# Altium schematic sheet Motor-X.SchDoc(Motor-X)
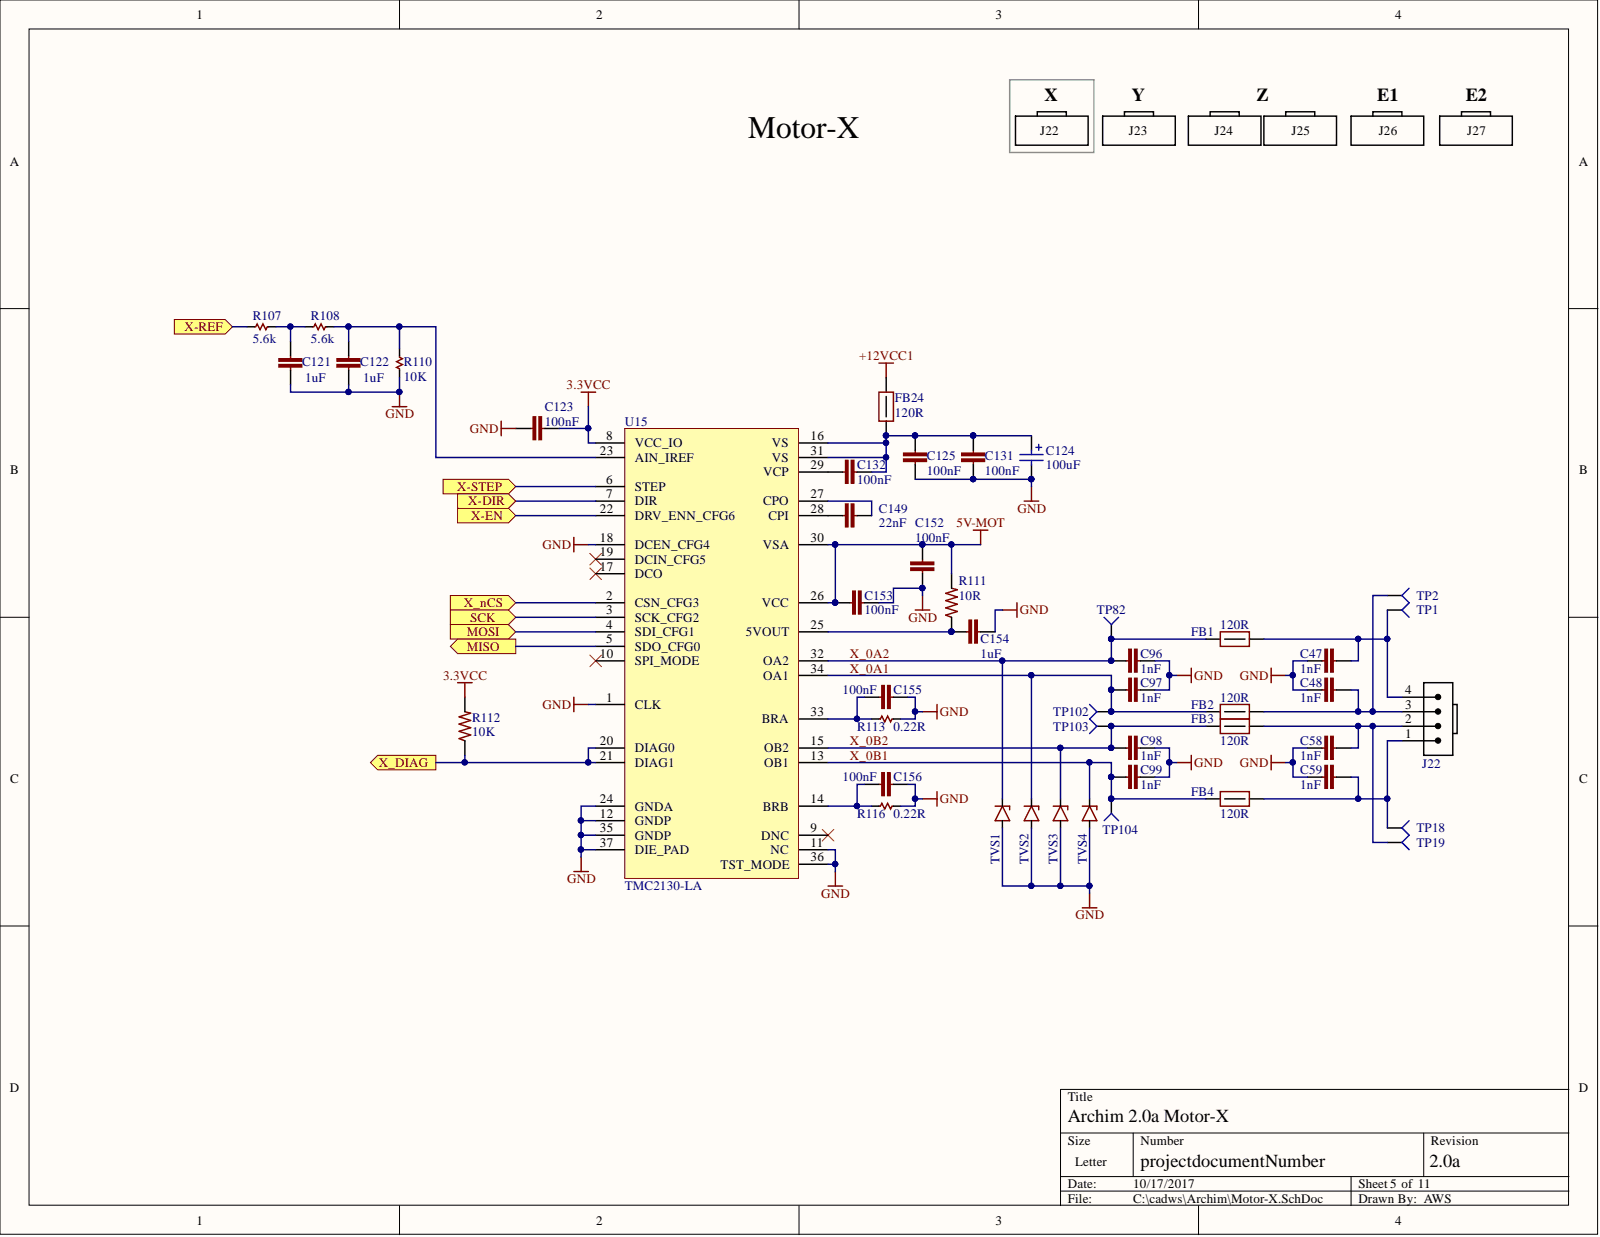
[diagram p0: Motor-X.SchDoc]

## Parts
C47  1nF  [C0402]
C48  1nF  [C0402]
C58  1nF  [C0402]
C59  1nF  [C0402]
C96  1nF  [C0402]
C97  1nF  [C0402]
C98  1nF  [C0402]
C99  1nF  [C0402]
C121  1uF  [C0402]
C122  1uF  [C0402]
C123  100nF  [C0402]
C124  value 100uF  [CAP-ELEC-063063077]  (hidden comment: UWT1V101MCL1GS)
C125  100nF  [C0603]
C131  100nF  [C0603]
C132  100nF  [C0603]
C149  22nF  [C0402]
C152  100nF  [C0402]
C153  100nF  [C0402]
C154  1uF  [C0402]
C155  100nF  [C0603]
C156  100nF  [C0603]
FB1  120R  [FB0603]
FB2  120R  [FB0603]
FB3  120R  [FB0603]
FB4  120R  [FB0603]
FB24  120R  [FB0603]
J22  Molex 0705430003  [4POL254]  (value not drawn)
R107  5.6k  [R0402]
R108  5.6k  [R0402]
R110  10K  [R0402]
R111  10R  [R0402]
R112  10K  [R0402]
R113  0.22R  [R1206]
R116  0.22R  [R1206]
TP1  TP4  [TP-2]  (value not drawn)  (no BOM)
TP2  TP4  [TP-2]  (value not drawn)  (no BOM)
TP18  TP4  [TP-2]  (value not drawn)  (no BOM)
TP19  TP4  [TP-2]  (value not drawn)  (no BOM)
TP82  TP4  [TP-2]  (value not drawn)  (no BOM)
TP102  TP4  [TP-2]  (value not drawn)  (no BOM)
TP103  TP4  [TP-2]  (value not drawn)  (no BOM)
TP104  TP4  [TP-2]  (value not drawn)  (no BOM)
TVS1  TVS 30V SMF  [SMF]  (value not drawn)
TVS2  TVS 30V SMF  [SMF]  (value not drawn)
TVS3  TVS 30V SMF  [SMF]  (value not drawn)
TVS4  TVS 30V SMF  [SMF]  (value not drawn)
U15  TMC2130-LA  [QFN36-50P500X600X900_HS-37N]

## Ports
MISO, MOSI, SCK, X-DIR, X-EN, X-REF, X-STEP, X_DIAG, X_nCS

## Nets
3.3VCC: C123.1 R112.2 U15.8(VCC_IO)
5V-MOT: C152.2 C153.2 R111.2 U15.26(VCC) U15.30(VSA)
+12VCC1: FB24.2
GND: C47.1 C48.1 C58.1 C59.1 C96.2 C97.2 C98.2 C99.2 C121.2 C122.2 C123.2 C124.2 C125.1 C131.1 C152.1 C153.1 C154.2 C155.1 C156.1 R110.1 R113.2 R116.2 TVS1.A TVS2.A TVS3.A TVS4.A U15.1(CLK) U15.11(NC) U15.12(GNDP) U15.18(DCEN_CFG4) U15.24(GNDA) U15.35(GNDP) U15.36(TST_MODE) U15.37(DIE_PAD)
MISO: U15.5(SDO_CFG0) ; port MISO
MOSI: U15.4(SDI_CFG1) ; port MOSI
SCK: U15.3(SCK_CFG2) ; port SCK
X-DIR: U15.7(DIR) ; port X-DIR
X-EN: U15.22(DRV_ENN_CFG6) ; port X-EN
X-REF: R107.1 ; port X-REF
X-STEP: U15.6(STEP) ; port X-STEP
X_0A1: C97.1 FB2.1 TP102.1 TVS2.K U15.34(OA1)
X_0A2: C96.1 FB1.1 TP82.1 TVS1.K U15.32(OA2)
X_0B1: C99.1 FB4.1 TP104.1 TVS4.K U15.13(OB1)
X_0B2: C98.1 FB3.1 TP103.1 TVS3.K U15.15(OB2)
X_DIAG: R112.1 U15.20(DIAG0) U15.21(DIAG1) ; port X_DIAG
X_nCS: U15.2(CSN_CFG3) ; port X_nCS
NetC47_2: C47.2 FB1.2 J22.4 TP1.1
NetC48_2: C48.2 FB2.2 J22.3 TP2.1
NetC58_2: C58.2 FB3.2 J22.2 TP19.1
NetC59_2: C59.2 FB4.2 J22.1 TP18.1
NetC121_1: C121.1 R107.2 R108.1
NetC122_1: C122.1 R108.2 R110.2 U15.23(AIN_IREF)
NetC124_1: C124.1 C125.2 C131.2 C132.2 FB24.1 U15.16(VS) U15.31(VS)
NetC132_1: C132.1 U15.29(VCP)
NetC149_1: C149.1 U15.28(CPI)
NetC149_2: C149.2 U15.27(CPO)
NetC154_1: C154.1 R111.1 U15.25(5VOUT)
NetC155_2: C155.2 R113.1 U15.33(BRA)
NetC156_2: C156.2 R116.1 U15.14(BRB)

Not connected: U15 9(DNC) 10(SPI_MODE) 17(DCO) 19(DCIN_CFG5)

## Library data of the parts
C47 C48 C58 C59 C96-C99 = CAP 1nF 50V 0402 X7R: 1000pF 50V Ceramic Capacitor X7R 0402 (1005 Metric) 0.039" L x 0.020" W (1.00mm x 0.50mm); PART DESCRIPTION: 1000pF ±10% 50V Ceramic Capacitor X7R 0402 (1005 Metric); PART: 1nF 50V X7R 0402; MANUFACTURER #: GRM155R71H102KA01D; MANUFACTURER: Murata; TOLERANCE: 10%; VALUE: 1nF; Library Name: 3Delight.SCHLIB; EE_SPEC: 50V; DISTRIBUTOR #: 490-1303-2-ND; DISTRIBUTOR: Digi-Key Electronics; Component Kind: Standard
C121 C122 = GRM155R60J105KE19D: CAP CER 0402 1uF 6.3V 10% X5R; PART DESCRIPTION: 1µF ±10% 6.3V Ceramic Capacitor X5R 0402 (1005 Metric); PART: 1uF 6.3V X5R 0402; MANUFACTURER: Murata; VALUE: 1uF; TOLERANCE: 10%; Simulation: Model Name; Signal Integrity: Model Name; MANUFACTURER #: GRM155R60J105KE19D; Library Name: 3Delight.SCHLIB; EE_SPEC: 1uF 6.3V X5R 0402; DISTRIBUTOR #: 490-1320-2-ND; DISTRIBUTOR: Digi-Key Electronics; Component Kind: Standard
C123 C152 C153 = GRM155R71C104KA88D: CAP CER 0402 100nF 16V 10% X7R; Part Description: 0.10µF ±10% 16V Ceramic Capacitor X7R 0402 (1005 Metric); PART: 0.1uF 16V X7R 0402; Manufacturer: Murata; EE_SPEC: 100nF 16V X7R 0402; VALUE: 100nF; Tolerance: 10%; Simulation: Model Name; Signal Integrity: Model Name; MANUFACTURER #: GRM155R71C104KA88D; Library Name: 3Delight.SCHLIB; DISTRIBUTOR #: 490-3261-2-ND; DISTRIBUTOR: Digi-Key Electronics; Component Kind: Standard
C124 = UWT1V101MCL1GS: CAP ALUM 100UF 35V 20% SMD; Part Description: 100µF 35V Aluminum Capacitors Radial, Can - SMD 1000 Hrs @ 105°C; PART: 100uF 35V SMD Al-El; Manufacturer: Nichicon; EE_SPEC: 100uF 35V Al-El; Tolerance: 20%; Simulation: CAP; Signal Integrity: Cap Pol; MANUFACTURER #: UWT1V101MCL1GS; Library Name: 3Delight.SCHLIB; DISTRIBUTOR #: 493-2203-2-ND; DISTRIBUTOR: Digi-Key Electronics; Component Kind: Standard
C125 C131 C132 C155 C156 = C0603C104K5RACTU: CAP CER 0603 100nF 50V 10% X7R; Part Description: 0.1µF ±10% 50V Ceramic Capacitor X7R 0603 (1608 Metric); PART: 100nF 50V X7R 0603; Manufacturer: Kemet; VALUE: 100nF; Tolerance: 10%; Simulation: Model Name; Signal Integrity: Model Name; MANUFACTURER #: C0603C104K5RACTU; Library Name: 3Delight.SCHLIB; EE_SPEC: 100nF 50V X7R 0603; DISTRIBUTOR #: 399-5089-2-ND; DISTRIBUTOR: Digi-Key Electronics; Component Kind: Standard
C149 = GCM155R71H223KA55D: CAP CER 0402 22nF ±10% 50V X7R; Part Description: 0.022µF ±10% 50V Ceramic Capacitor X7R 0402 (1005 Metric); Manufacturer: YAGEO; Tolerance: +/- 10%; EE_SPEC: 22nF 50V X7R 0402; VALUE: 22nF; Simulation: Model Name; Signal Integrity: Model Name; PART: 22nF; MANUFACTURER #: GCM155R71H223KA55D; Library Name: 3Delight.SCHLIB; DISTRIBUTOR #: 490-4763-2-ND; DISTRIBUTOR: Digi-Key Electronics; Component Kind: Standard
C154 = GRM155R60J105KE19D: CAP CER 0402 1uF 6.3V 10% X5R; Part Description: 1µF ±10% 6.3V Ceramic Capacitor X5R 0402 (1005 Metric); PART: 1uF 6.3V X5R 0402; Manufacturer: Murata; VALUE: 1uF; Tolerance: 10%; Simulation: Model Name; Signal Integrity: Model Name; MANUFACTURER #: GRM155R60J105KE19D; Library Name: 3Delight.SCHLIB; EE_SPEC: 1uF 6.3V X5R 0402; DISTRIBUTOR #: 490-1320-2-ND; DISTRIBUTOR: Digi-Key Electronics; Component Kind: Standard
FB1-FB4 = FERRITE 120R 3A 0603: FERRITE BEAD 120 OHM 0603 1LN; MANUFACTURER #: CIS10P121AC; MANUFACTURER2#: 490-5254-2-ND; MANUFACTURER: Samsung; VALUE: 120Rfb; TOLERANCE: -NA-; EE_SPEC: 3A; PART DESCRIPTION: FERRITE BEAD 120 OHM 0603 1LN; PART: FERRITE 120R 3A 0603; Library Name: 3Delight.SCHLIB; DISTRIBUTOR #: 1276-6351-2-ND; DISTRIBUTOR: Digi-Key Electronics; Component Kind: Standard
FB24 = FERRITE 120R 3A 0603: FERRITE BEAD 120 OHM 0603 1LN; MANUFACTURER #: CIS10P121AC; MANUFACTURER: Samsung; VALUE: 120Rfb; TOLERANCE: -NA-; EE_SPEC: 3A; PART DESCRIPTION: FERRITE BEAD 120 OHM 0603 1LN; PART: FERRITE 120R 3A 0603; Library Name: Inductors.SchLib; DISTRIBUTOR #: 1276-6351-2-ND; DISTRIBUTOR: Digi-Key Electronics; Component Kind: Standard
J22 = Molex 0705430003 Alt_1: Molex 4 Pin Clip Connector; PART DESCRIPTION: 4 Positions Header, Shrouded Connector 0.100" (2.54mm) Through Hole Tin; PART: 4 Pin Conn; MANUFACTURER #: 0705430038; VALUE: HDR4; TOLERANCE: -NA-; MANUFACTURER: Molex; EE_SPEC: -NA-; DISTRIBUTOR #: WM4826-ND; DISTRIBUTOR: Digi-Key Electronics; Component Kind: Standard; Library Name: Archim.SCHLIB
R107 R108 = 5.6k 1% 0402: RES SMD 5.6K OHM 1% 1/16W 0402; PART DESCRIPTION: ±1% 0.063W, 1/16W Chip Resistor 0402 (1005 Metric) Moisture Resistant Thick Film; MANUFACTURER #: RC0402FR-075K6L; MANUFACTURER: YAGEO; VALUE: 5.6k; TOLERANCE: 1%; PART: RES SMD 5.6K OHM 1% 1/16W 0402; Library Name: Resistors.SchLib; EE_SPEC: 1/16W; DISTRIBUTOR #: 311-5.6KLRTR-ND; DISTRIBUTOR: Digi-Key Electronics; Component Kind: Standard
R110 = 10k 1% 0402: RES SMD 10K OHM 1% 1/16W 0402; PART DESCRIPTION: 10k Ohm ±1% 0.063W, 1/16W Chip Resistor 0402 (1005 Metric) Moisture Resistant Thick Film; MANUFACTURER #: RC0402FR-0710KP; MANUFACTURER: Yageo; VALUE: 10K; TOLERANCE: 1%; Simulation: Model Name; Signal Integrity: Model Name; PART: 10k 1% 0402; Library Name: Resistors.SchLib; EE_SPEC: 1/16W; DISTRIBUTOR #: YAG1249TR-ND; DISTRIBUTOR: Digi-Key Electronics; Component Kind: Standard
R111 = RC0402FR-0710RL: RES SMD 10 OHM 1% 1/16W 0402; Part Description: 10 Ohm ±1% 0.063W, 1/16W Chip Resistor 0402 (1005 Metric) Moisture Resistant Thick Film; Manufacturer: Yageo; EE_SPEC: 10R 1% 0402; VALUE: 10R; Tolerance: 1%; Simulation: Model Name; Signal Integrity: Model Name; PART: 10R; MANUFACTURER #: RC0402FR-0710RL; Library Name: 3Delight.SCHLIB; DISTRIBUTOR #: 311-10.0LRTR-ND; DISTRIBUTOR: Digi-Key Electronics; Component Kind: Standard
R112 = RC0402FR-0710KP: RES SMD 10K OHM 1% 1/16W 0402; PART DESCRIPTION: 10k Ohm ±1% 0.063W, 1/16W Chip Resistor 0402 (1005 Metric) Moisture Resistant Thick Film; PART: 10k 1% 0402; MANUFACTURER: Yageo; VALUE: 10K; TOLERANCE: 1%; Simulation: Model Name; Signal Integrity: Model Name; MANUFACTURER #: RC0402FR-0710KP; Library Name: 3Delight.SCHLIB; EE_SPEC: 1/16W; DISTRIBUTOR #: YAG1249TR-ND; DISTRIBUTOR: Digi-Key Electronics; Component Kind: Standard
R113 R116 = 0.22R 1% 1206: RES SMD 0.22 OHM 1% 1/2W 1206; Part Description: 0.22 Ohm ±1% 0.5W, 1/2W Chip Resistor 1206 (3216 Metric) Automotive AEC-Q200, Current Sense Thick Film; MANUFACTURER #: ERJ-8BQFR22V; Manufacturer: Panasonic; Tolerance: +/- 1%; VALUE: 0.22R; PART: 0.22R; Library Name: Resistors.SchLib; EE_SPEC: 0.22R 1% 1206; DISTRIBUTOR #: P17624TR-ND; DISTRIBUTOR: Digi-Key Electronics; Component Kind: Standard
TP1 TP2 TP18 TP19 TP82 TP102-TP104 = Socket: MANUFACTURER #: NOTAPART-Testpoint; Signal Integrity: Connector; Description: Socket; Library Name: Archim.SCHLIB
TVS1-TVS4 = TVS 30V SMF: TVS DIODE 30VWM 48.4VC SMF; PART: TVS Diode 48.4VC; MANUFACTURER #: SMF30A-E3-08; MANUFACTURER: Vishay; TOLERANCE: -NA-; EE_SPEC: 30Vrs 48.4Vc; PART DESCRIPTION: TVS DIODE 30VWM 48.4VC SMF; Library Name: 3Delight.SCHLIB; DISTRIBUTOR #: SMF30A-E3-08GITR-ND; DISTRIBUTOR: Digi-Key Electronics; Component Kind: Standard
U15 = TMC2130-LA-T: IC STEPPER MOTOR DVR SPI 36QFN; Part Description: Bipolar Motor Driver Power MOSFET SPI, Step/Direction 36-QFN (5x6); Manufacturer: Trinamic; Tolerance: NA; EE_SPEC: 46V 1.2A 1/256 Step; VALUE: TMC2130; PART: TMC2130; MANUFACTURER #: TMC2130-LA-T; Library Name: ICs-Motor Controller.SchLib; DISTRIBUTOR #: 1460-1130-2-ND; DISTRIBUTOR: Digi-Key Electronics; Component Kind: Standard
